# Altium schematic sheet GPIO_Expander.SchDoc(GPIO_Expander)
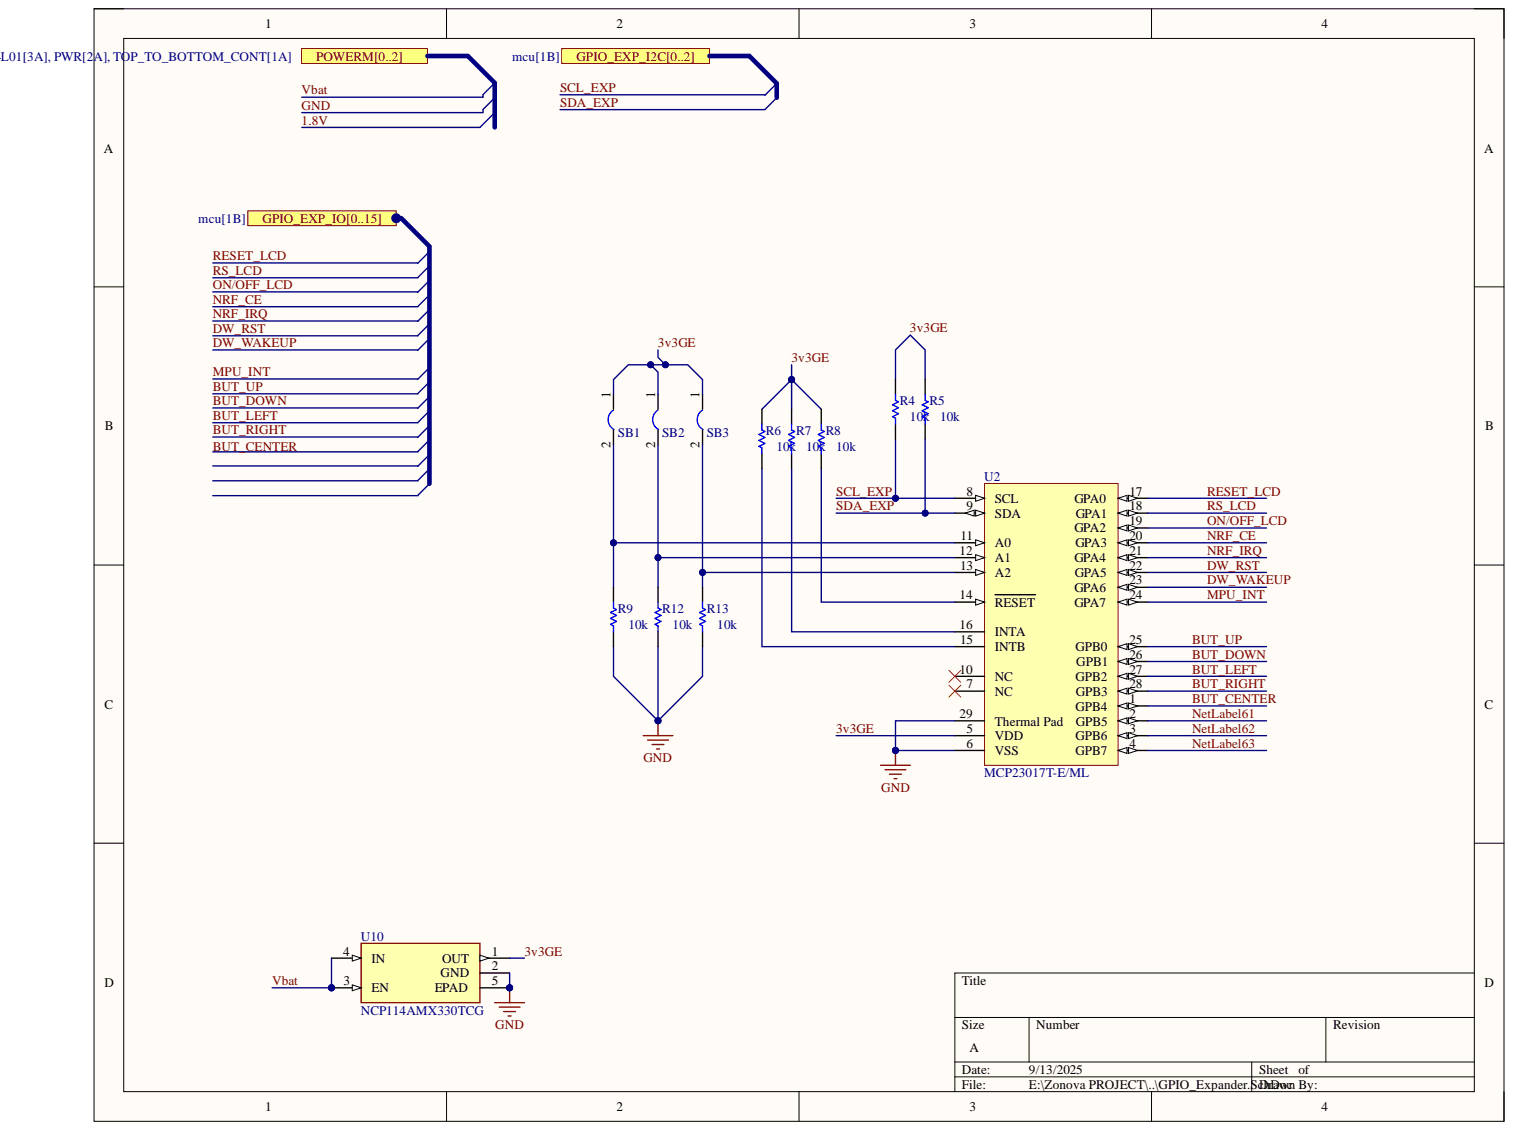
[diagram p0: GPIO_Expander.SchDoc]

## Parts
R4  0402WGF1002TCE  [RESC1005X40X25LL05T10]  (value not drawn)
R5  resistance: 10k  [RESC1005X40X25LL05T10]  (hidden comment: 0402WGF1002TCE)
R6  0402WGF1002TCE  [RESC1005X40X25LL05T10]  (value not drawn)
R7  resistance: 10k  [RESC1005X40X25LL05T10]  (hidden comment: 0402WGF1002TCE)
R8  resistance: 10k  [RESC1005X40X25LL05T10]  (hidden comment: 0402WGF1002TCE)
R9  resistance: 10k  [RESC1005X40X25LL05T10]  (hidden comment: 0402WGF1002TCE)
R12  resistance: 10k  [RESC1005X40X25LL05T10]  (hidden comment: 0402WGF1002TCE)
R13  resistance: 10k  [RESC1005X40X25LL05T10]  (hidden comment: 0402WGF1002TCE)
SB1  Jumper  [Jumper]  (value not drawn)
SB2  Jumper  [Jumper]  (value not drawn)
SB3  Jumper  [Jumper]  (value not drawn)
U2  MCP23017T-E/ML  [QFN-ML28_M]
U10  NCP114AMX330TCG  [REG_NCP114AMX330TCG]

## Ports
GPIO_EXP_I2C0, GPIO_EXP_I2C1, GPIO_EXP_I2C2, GPIO_EXP_I2C[0..2], GPIO_EXP_IO0, GPIO_EXP_IO1, GPIO_EXP_IO2, GPIO_EXP_IO3, GPIO_EXP_IO4, GPIO_EXP_IO5, GPIO_EXP_IO6, GPIO_EXP_IO7, GPIO_EXP_IO8, GPIO_EXP_IO9, GPIO_EXP_IO10, GPIO_EXP_IO11, GPIO_EXP_IO12, GPIO_EXP_IO13, GPIO_EXP_IO14, GPIO_EXP_IO15, GPIO_EXP_IO[0..15], POWERM0, POWERM1, POWERM2, POWERM[0..2]

## Nets
3v3GE: R4.2 R5.2 R6.2 R7.2 R8.2 SB1.1 SB2.1 SB3.1 U2.5(VDD) U10.1(OUT)
BUT_CENTER: U2.1(GPB4)
BUT_DOWN: U2.26(GPB1)
BUT_LEFT: U2.27(GPB2)
BUT_RIGHT: U2.28(GPB3)
BUT_UP: U2.25(GPB0)
DW_RST: U2.22(GPA5)
DW_WAKEUP: U2.23(GPA6)
GND: R9.1 R12.1 R13.1 U2.6(VSS) U2.29 U10.2(GND) U10.5(EPAD)
MPU_INT: U2.24(GPA7)
NetLabel61: U2.2(GPB5)
NetLabel62: U2.3(GPB6)
NetLabel63: U2.4(GPB7)
NRF_CE: U2.20(GPA3)
NRF_IRQ: U2.21(GPA4)
ON/OFF_LCD: U2.19(GPA2)
RESET_LCD: U2.17(GPA0)
RS_LCD: U2.18(GPA1)
SCL_EXP: R4.1 U2.8(SCL)
SDA_EXP: R5.1 U2.9(SDA)
Vbat: U10.3(EN) U10.4(IN)
NetR6_1: R6.1 U2.15(INTB)
NetR7_1: R7.1 U2.16(INTA)
NetR8_1: R8.1 U2.14(RESET)
NetR9_2: R9.2 SB1.2 U2.11(A0)
NetR12_2: R12.2 SB2.2 U2.12(A1)
NetR13_2: R13.2 SB3.2 U2.13(A2)
1.8V:
N00007_0: ; port POWERM[0..2]
N00007_1: ; port POWERM[0..2]
N00007_2: ; port POWERM[0..2]
N00015_0: ; port GPIO_EXP_I2C[0..2]
N00015_1: ; port GPIO_EXP_I2C[0..2]
N00015_2: ; port GPIO_EXP_I2C[0..2]
N00025_0: ; port GPIO_EXP_IO[0..15]
N00025_1: ; port GPIO_EXP_IO[0..15]
N00025_2: ; port GPIO_EXP_IO[0..15]
N00025_3: ; port GPIO_EXP_IO[0..15]
N00025_4: ; port GPIO_EXP_IO[0..15]
N00025_5: ; port GPIO_EXP_IO[0..15]
N00025_6: ; port GPIO_EXP_IO[0..15]
N00025_7: ; port GPIO_EXP_IO[0..15]
N00025_8: ; port GPIO_EXP_IO[0..15]
N00025_9: ; port GPIO_EXP_IO[0..15]
N00025_10: ; port GPIO_EXP_IO[0..15]
N00025_11: ; port GPIO_EXP_IO[0..15]
N00025_12: ; port GPIO_EXP_IO[0..15]
N00025_13: ; port GPIO_EXP_IO[0..15]
N00025_14: ; port GPIO_EXP_IO[0..15]
N00025_15: ; port GPIO_EXP_IO[0..15]

Not connected: U2 7(NC) 10(NC)

## Library data of the parts
R4 R6 = CMP-2002-03043-1: Manufacturer: UNI-ROYAL(Uniroyal Elec); resistance: 10k; Component Kind: Standard; JLCPCB Part #: C25744; Library Name: Altium Content Vault; MFR.Part #: 0402WGF1002TCE; Part Number: 0402WGF1002TCE
R5 R7-R9 R12 R13 = CMP-2002-03043-1: Manufacturer: UNI-ROYAL(Uniroyal Elec); Component Kind: Standard; JLCPCB Part #: C25744; Library Name: Altium Content Vault; MFR.Part #: 0402WGF1002TCE; Part Number: 0402WGF1002TCE
U2 = CMP-0186-00119-2: 16-Bit I/O Expander with Serial Interface, 28-Pin QFN, Extended Temperature, Tape and Reel; Code_JEDEC: MO-220; Component Kind: Standard; ComponentLink1Description: Manufacturer URL; ComponentLink1URL: http://www.microchip.com/; ComponentLink2Description: Datasheet; ComponentLink2URL: http://ww1.microchip.com/downloads/en/DeviceDoc/21952b.pdf; ComponentLink3Description: Package Specification; ComponentLink3URL: http://www.microchip.com/stellent/groups/techpub_sg/documents/packagingspec/en012702.pdf; DatasheetVersion: revB, Feb-2007; Library Name: Altium Content Vault; PackageDescription: 28-Lead Plastic Quad Flat, No Lead Package (ML) - 6x6 mm Body [QFN] with 0.55 mm Contact Length; PackageReference: QFN-ML28; PackageVersion: revBB, Aug-2009; RevisionNote: URLs added.
U10 = NCP114AMX330TCG: Linear Voltage Regulator IC Positive Fixed 1 Output 300mA 4-UDFN (1.0x1.0); MF: ON Semiconductor; Check_prices: https://www.snapeda.com/parts/NCP114AMX330TCG/Onsemi/view-part/?ref=eda; Component Kind: Standard; Library Name: NCP114AMX330TCG.IntLib; MP: NCP114AMX330TCG; Package: UDFN-4 ON Semiconductor; SnapEDA_Link: https://www.snapeda.com/parts/NCP114AMX330TCG/Onsemi/view-part/?ref=snap
SB1-SB3 = Jumper: Component Kind: Standard; Library Name: Integrated_Library2.IntLib
